# Altium schematic sheet Tachometer Interface.SchDoc("Tachometer Interface")
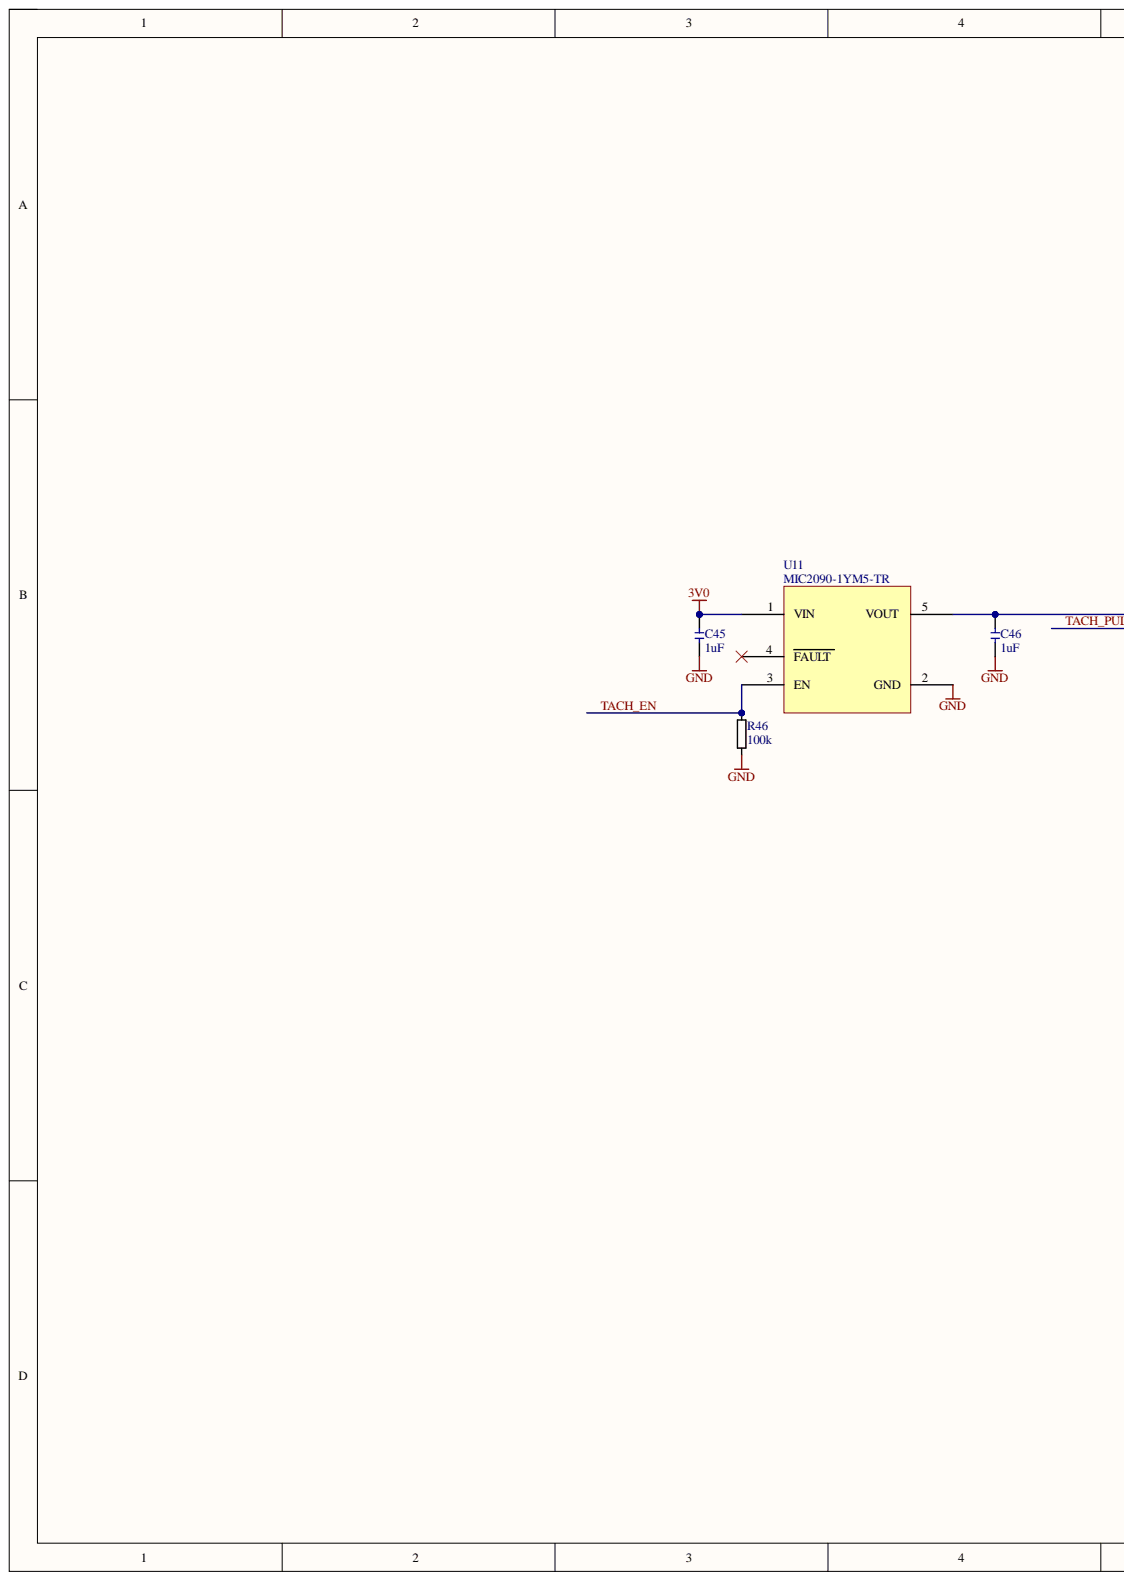
[diagram p0: Tachometer Interface.SchDoc, left]
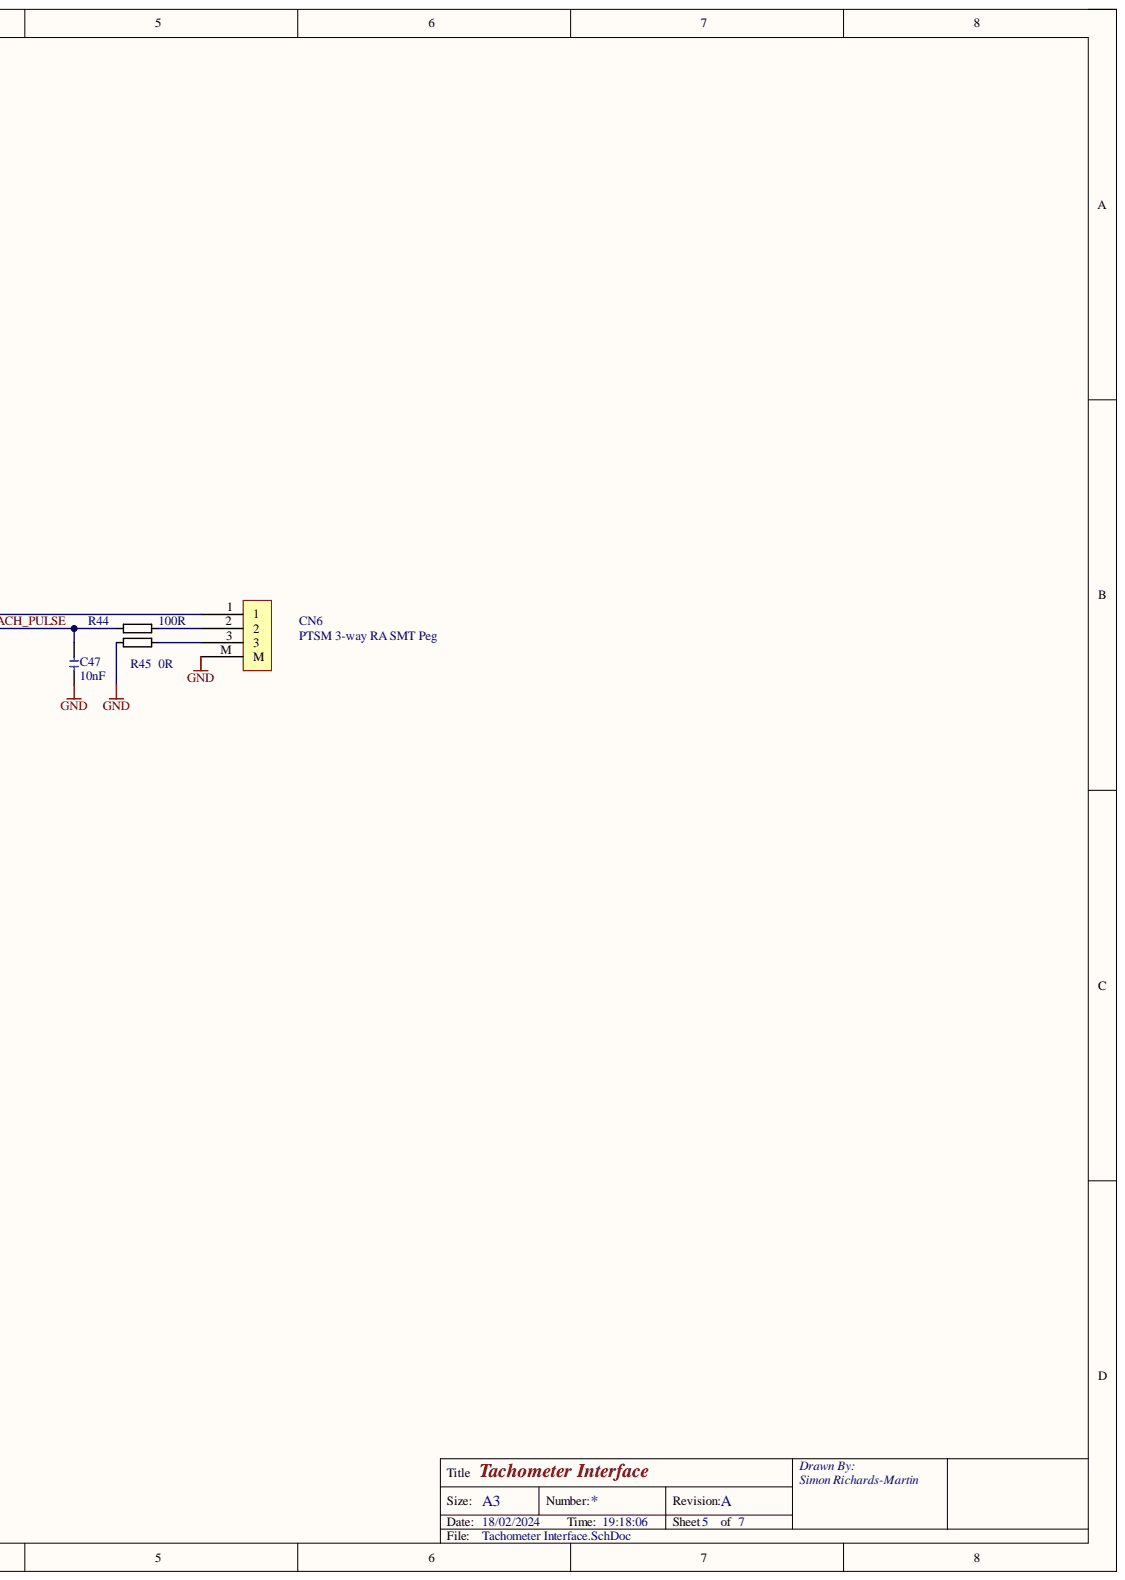
[diagram p1: Tachometer Interface.SchDoc, right]

## Parts
C45  1uF  [CHIP-0402]
C46  1uF  [CHIP-0402]
C47  10nF  [CHIP-0402]
CN6  PTSM 3-way RA SMT Peg  [1778777]
R44  100R  [CHIP-0402]
R45  0R  [CHIP-0402]
R46  100k  [CHIP-0402]
U11  MIC2090-1YM5-TR  [SOT23-5]

## Nets
3V0: C45.1 U11.1(VIN)
GND: C45.2 C46.2 C47.2 CN6.M R45.1 R46.1 U11.2(GND)
TACH_EN: R46.2 U11.3(EN)
TACH_PULSE: C47.1 R44.1
NetC46_1: C46.1 CN6.1 U11.5(VOUT)
NetCN6_2: CN6.2 R44.2
NetCN6_3: CN6.3 R45.2

Not connected: U11 4(FAULT)

## Library data of the parts
C45 C46 = Capacitor 1uF 50V 0402 X5R: Manufacturer: Murata; MPN: GRM155R61H105KE05D; Component Kind: Standard; Description: Capacitor 1uF 50V 0402 X5R; Library Name: Paramotor Sensor Main.SchLib
C47 = Capacitor 10nF 25V 0402 C0G: Manufacturer: Murata; MPN: GRM1555C1E103GE01D; Component Kind: Standard; Description: Capacitor 10nF 25V 0402 C0G; Library Name: Paramotor Sensor Main.SchLib
CN6 = PTSM 3-way RA SMT Peg: Manufacturer: Phoenix Contact; Component Kind: Standard; MPN: 1778777; Library Name: Paramotor Sensor Main.SchLib
R44 = Resistor 100R 1% 0402: Manufacturer: Yageo; MPN: AC0402FR-07100RL; Component Kind: Standard; Description: Resistor 100R 1% 0402; Library Name: Paramotor Sensor Main.SchLib
R45 = Resistor 0R 0402: Manufacturer: Yageo; MPN: RC0402FR-070RL; Component Kind: Standard; Description: Resistor 0R 0402; Library Name: Paramotor Sensor Main.SchLib
R46 = Resistor 100k 1% 0402: Manufacturer: Yageo; MPN: RC0402FR-07100KL; Component Kind: Standard; Description: Resistor 100k 1% 0402; Library Name: Paramotor Sensor Main.SchLib
U11 = MIC2090-1YM5-TR: Load Switch Current Limit 50mA SOT23-5; Manufacturer: Microchip; Component Kind: Standard; MPN: MIC2090-1YM5-TR; Library Name: Paramotor Sensor Main.SchLib
